# Altium schematic sheet Power.SchDoc
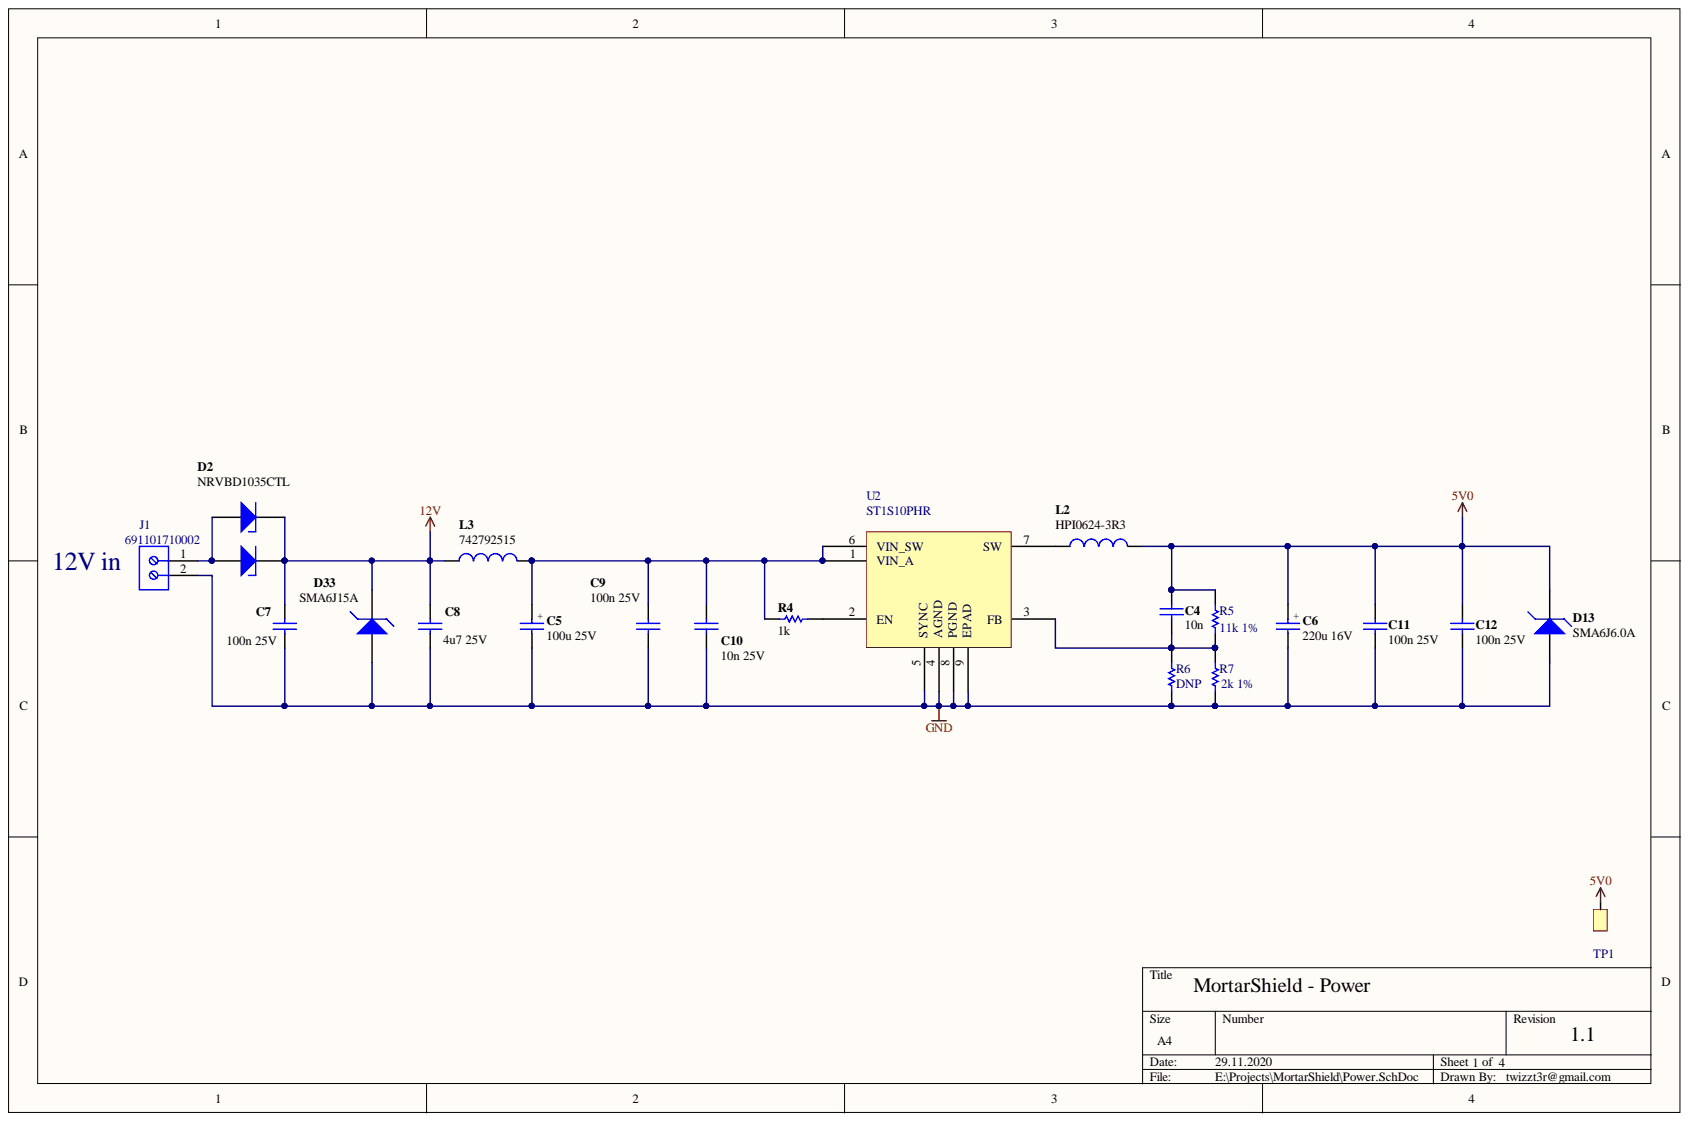
[diagram p0: Power.SchDoc]

## Parts
C4  10n  [C_0603]
C5  value 100u 25V  [WCAP-ASLL_6.3x7.7]  (hidden comment: C_100u_25V_radial)
C6  value 220u 16V  [WCAP-ASLL_6.3x7.7]  (hidden comment: C_220u_16V_radial)
C7  value 100n 25V  [C_0603]  (hidden comment: C_100n_25V_0603)
C8  value 4u7 25V  [C_0603]  (hidden comment: C_4u7_16V_0603)
C9  value 100n 25V  [C_0603]  (hidden comment: C_100n_25V_0603)
C10  value 10n 25V  [C_0603]  (hidden comment: C_100n_25V_0603)
C11  value 100n 25V  [C_0603]  (hidden comment: C_100n_25V_0603)
C12  value 100n 25V  [C_0603]  (hidden comment: C_100n_25V_0603)
D2  NRVBD1035CTL  [DPAK228P1000X238-3N]
D13  SMA6J6.0A  [D_SMA]
D33  SMA6J15A  [D_SMA]
J1  691101710002  [691102710002]
L2  HPI0624-3R3  [L_HPI0624-3R3]
L3  742792515  [L_1812]
R4  value 1k  [R_0603]  (hidden comment: R_0402)
R5  value 11k 1%  [R_0603]  (hidden comment: R_0603)
R6  DNP  [R_0603]
R7  value 2k 1%  [R_0603]  (hidden comment: R_0603)
TP1  testpoint  [HDR_1]  (value not drawn)
U2  ST1S10PHR  [PowerSO-8]

## Nets
5V0: C4.2 C6.2 C11.2 C12.2 D13.2 L2.1 R5.2 TP1.1
12V: C7.2 C8.2 D2.4 D33.2 L3.2
GND: C5.1 C6.1 C7.1 C8.1 C9.1 C10.1 C11.1 C12.1 D13.1 D33.1 J1.2 R6.1 R7.1 U2.4(AGND) U2.5(SYNC) U2.8(PGND) U2.9(EPAD)
NetC4_1: C4.1 R5.1 R6.2 R7.2 U2.3(FB)
NetC5_2: C5.2 C9.2 C10.2 L3.1 R4.1 U2.1(VIN_A) U2.6(VIN_SW)
NetD2_1: D2.1 D2.3 J1.1
NetL2_2: L2.2 U2.7(SW)
NetR4_2: R4.2 U2.2(EN)

## Library data of the parts
C4 = C_0603: mfg_pn: CL10B103KB8NNNC; Component Kind: Standard; Value: 10n
C5 = C_100u_25V_radial: Rated Voltage: 25V; Component Kind: Standard; Library Name: SCH.SchLib
C6 = C_220u_16V_radial: mfg_pn: 865060345007; Rated Voltage: 16v; Value: 220u 16V; Component Kind: Standard; Library Name: SCH.SchLib
C7 C9-C12 = C_100n_25V_0603: 100n 25V 0603 X7R; mfg_pn: 885012206071; Component Kind: Standard; Library Name: Kayon_01.SCHLIB
C8 = C_4u7_16V_0603: 4u7 16V 0603 X6S; mfg_pn: C1608X6S1C475K080AC; Component Kind: Standard; Library Name: Kayon_01.SCHLIB
D2 = D_SHCHOTTKY_NRVBD1035CTL: Switch-mode Schottky Power Rectifier; Component Kind: Standard; Library Name: SCH.SchLib; mfg_pn: NRVBD1035CTL
D13 D33 = D_TVS: TVS; Component Kind: Standard; Library Name: SCH.SchLib
J1 = 691101710002: WR-TBL Serie 101 Horizontal Entry Modular, Pressure Clamp, pitch 5mm, 2p; Compliance: Lead free / RoHS; Modularity: Yes; PCB/Cable/Panel: PCB; Pin Number: 2; Rated Current [UL]: 16A; Working Voltage [UL]: 250VAC; Application: Pressure Clamp; Component Kind: Standard; ComponentLink1Description: Manufacturer; ComponentLink1URL: http://www.we-online.de; ComponentLink2Description: Datasheet; ComponentLink2URL: https://katalog.we-online.de/em/datasheet/691101710002.pdf; mfg_pn: 691101710002; Mounting Technology: THT; Operating Temperature: -40 to +105degC; Packaging: Box; Pitch: 5mm; Published Date: October 2019; Type: Horizontal; Library Name: Kayon_01.SCHLIB; Publisher: Wurth Elektronik
L2 = L_0603: SMD-Multilayer Inductor; Name: 2009; Component Kind: Standard; mfg_pn: HPI0624-3R3
L3 = L_0603: SMD-Multilayer Inductor; Component Kind: Standard; mfg_pn: 742792515
R4 = R_0402: 0402 (1005 Metric)  SMD; Case-EIA: 0402; Case-Metric: 1005; Power: 0.063 W; Tolerance: 5 %; Component Kind: Standard; Technology: SMT
R5 = R_0603: mfg_pn: CPF0603F11KC1; Component Kind: Standard; Library Name: Kayon_01.SCHLIB
R6 = R_0603: Component Kind: Standard; value: DNP; Library Name: Kayon_01.SCHLIB
R7 = R_0603: mfg_pn: R0603-FX-2001EPF; Component Kind: Standard; Library Name: Kayon_01.SCHLIB
TP1 = TP: Component Kind: Standard; Library Name: SCH.SchLib
U2 = ST1S10PHR: Component Kind: Standard; mfg_pn: ST1S10PHR; Library Name: Kayon_01.SCHLIB
